# Altium schematic sheet SCT3811 and CMX940 EVM_V2_20220408.SCHDOC
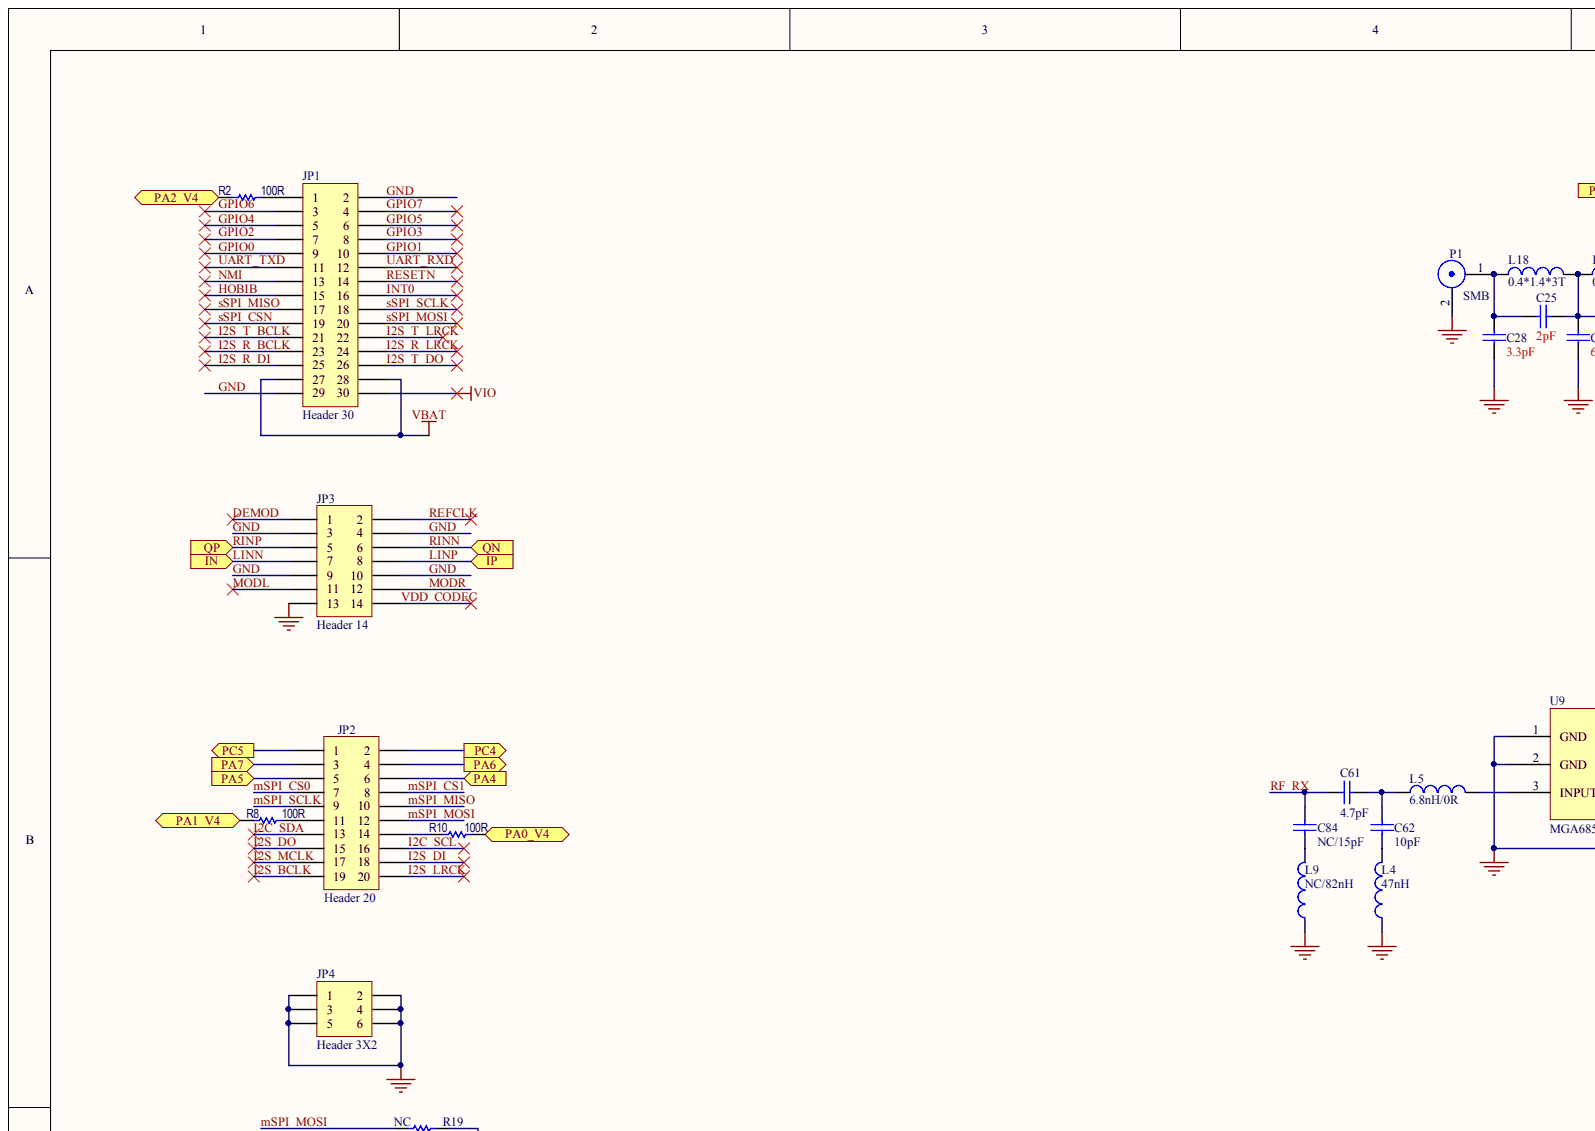
[diagram p0: SCT3811 and CMX940 EVM_V2_20220408.SCHDOC, top left]
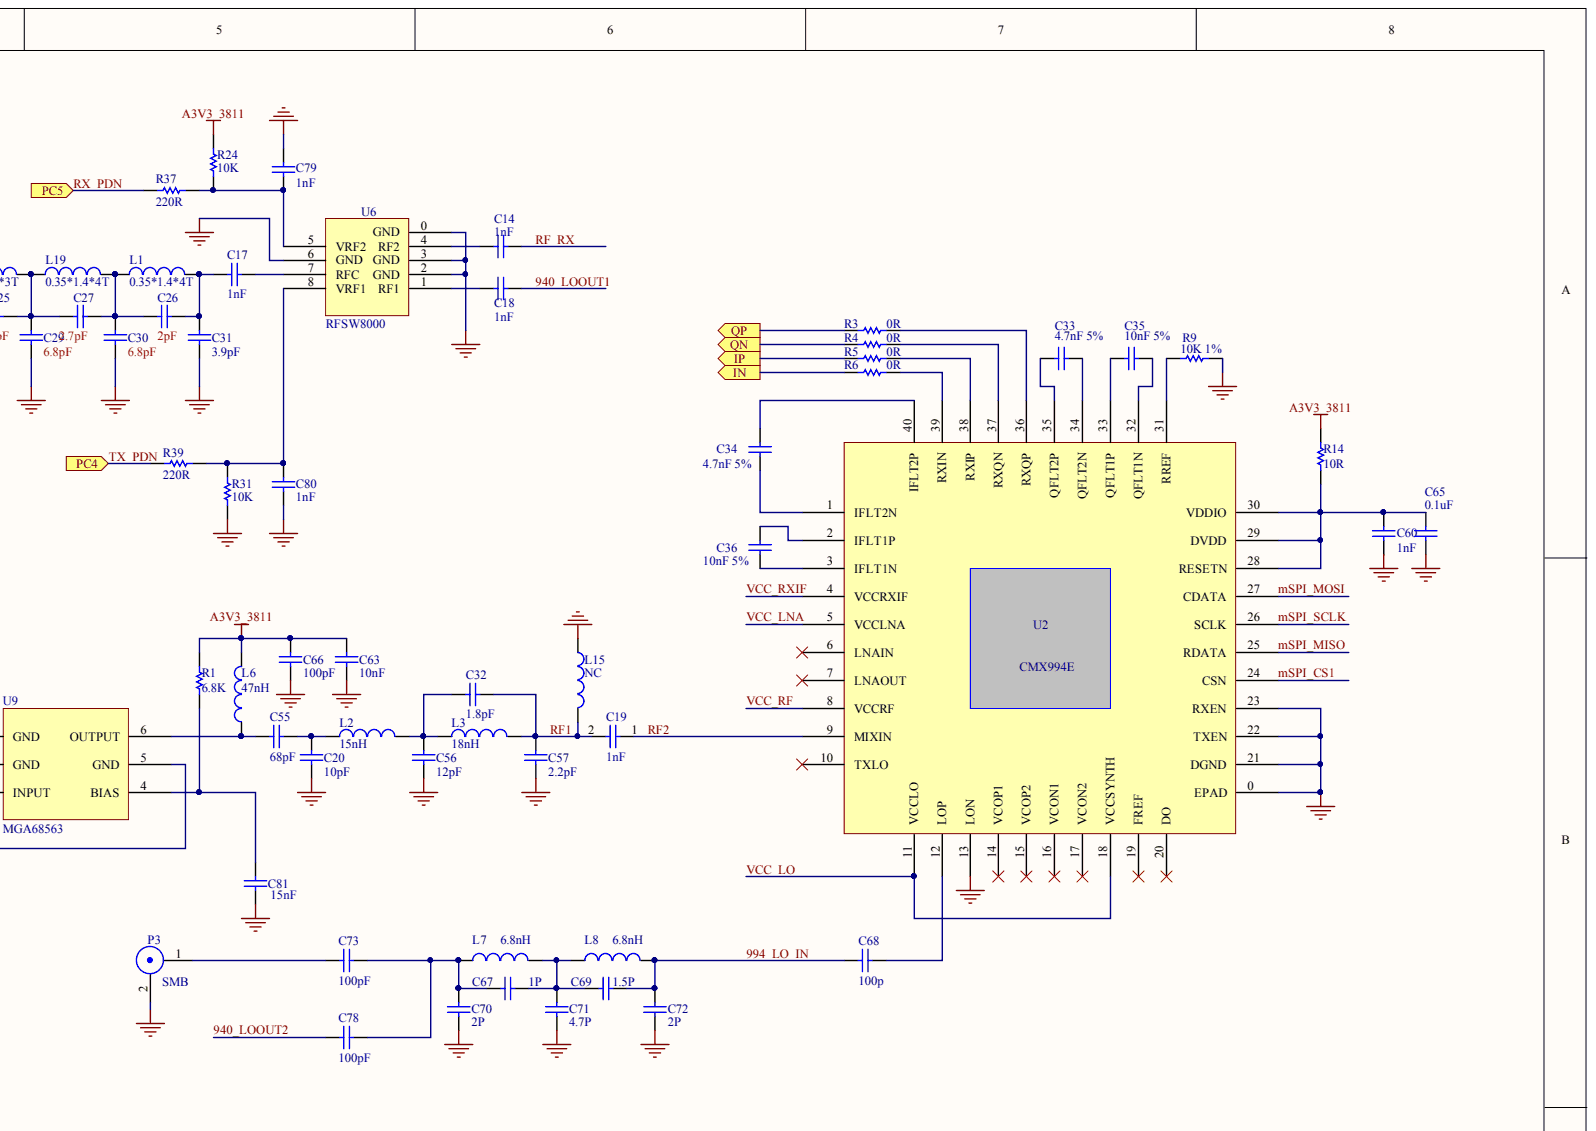
[diagram p1: SCT3811 and CMX940 EVM_V2_20220408.SCHDOC, top right]
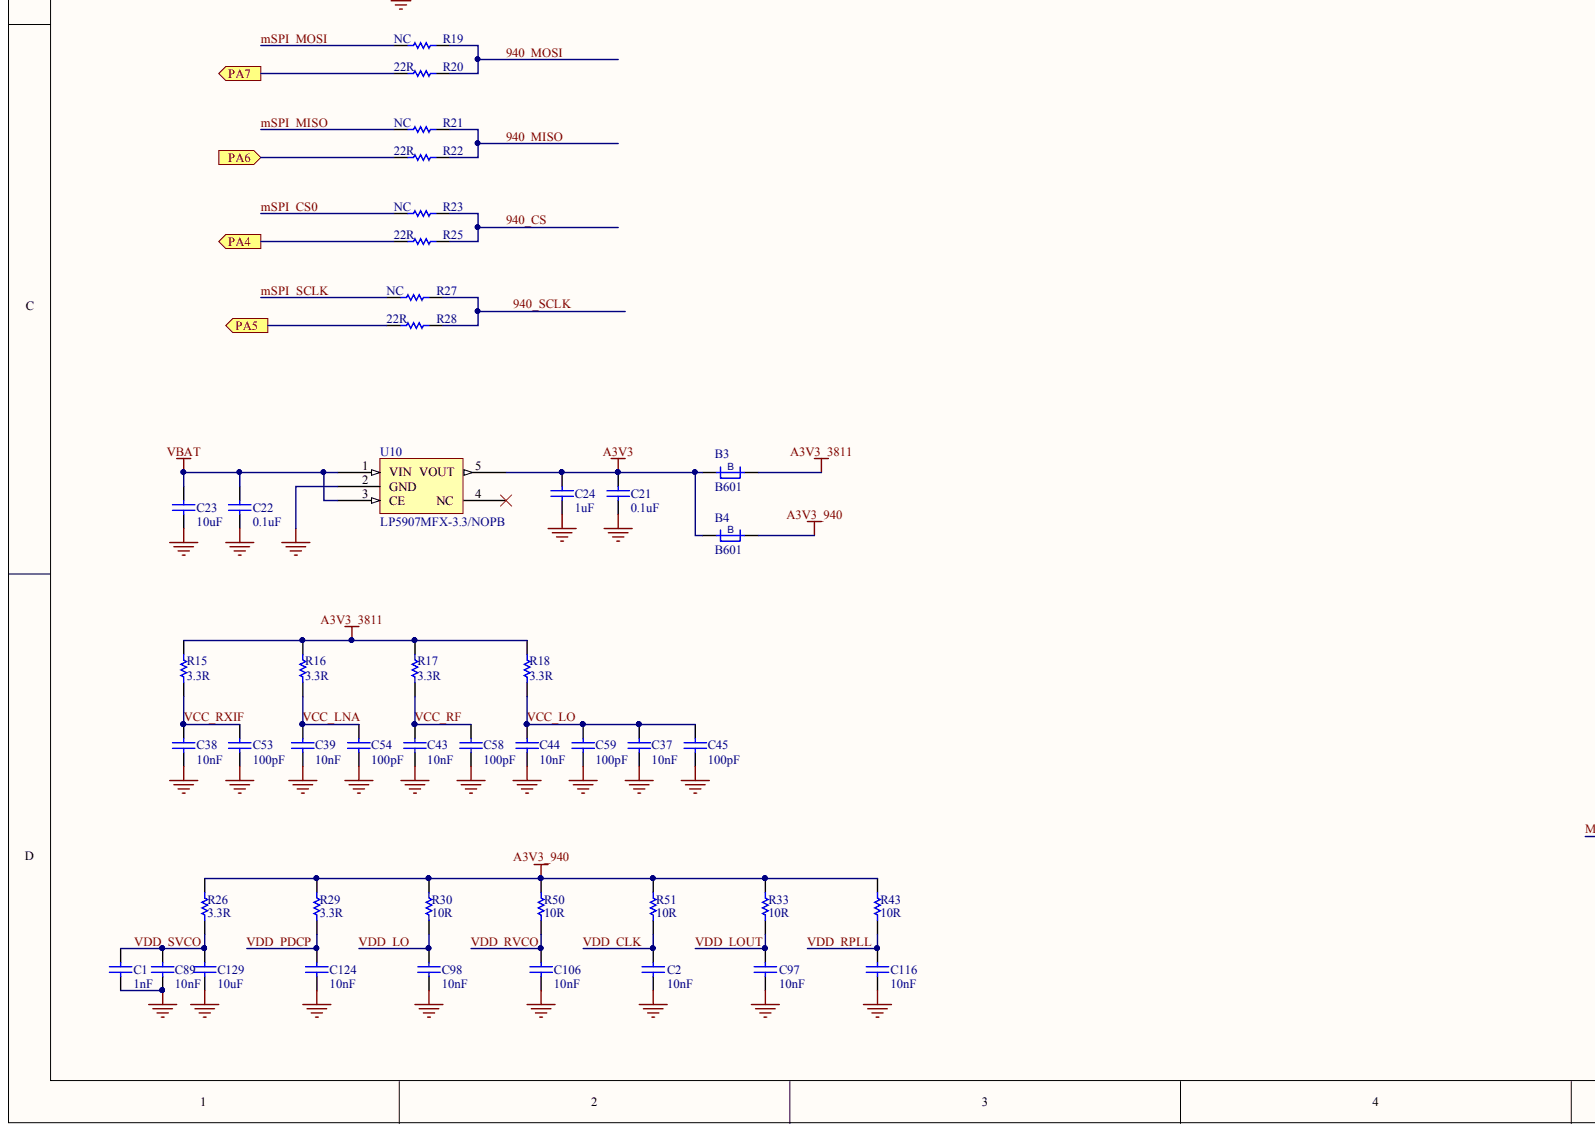
[diagram p2: SCT3811 and CMX940 EVM_V2_20220408.SCHDOC, bottom left]
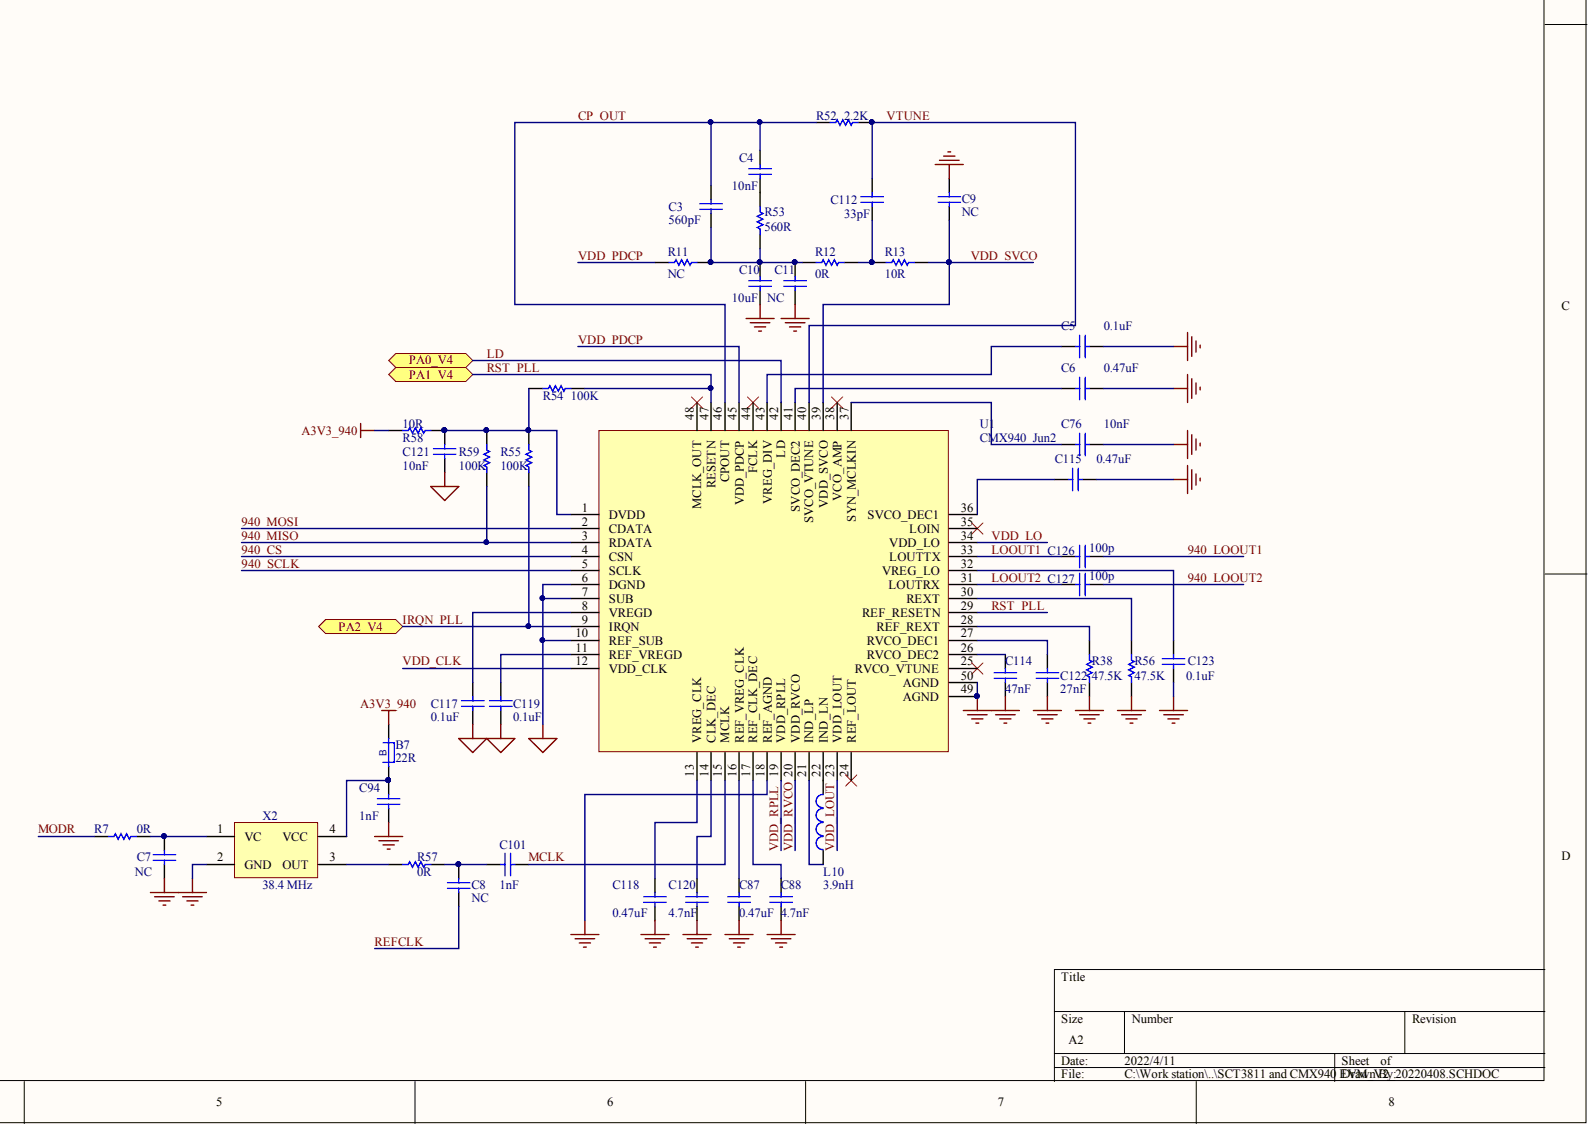
[diagram p3: SCT3811 and CMX940 EVM_V2_20220408.SCHDOC, bottom right]

## Parts
B3  B601  [0402]
B4  B601  [0402]
B7  22R  [0402]
C1  1nF  [0402]
C2  10nF  [0402]
C3  560pF  [0402]
C4  10nF  [0603S]
C5  0.1uF  [0402]
C6  0.47uF  [0402]
C7  NC  [0402]
C8  NC  [0402]
C9  NC  [0603S]
C10  10uF  [0603]
C11  NC  [0603S]
C14  1nF  [0402]
C17  1nF  [0402]
C18  1nF  [0402]
C19  1nF  [0402]
C20  10pF  [0402]
C21  0.1uF  [0402]
C22  0.1uF  [0402]
C23  10uF  [0603]
C24  1uF  [0402]
C25  2pF  [0603-MINI]
C26  2pF  [0603-MINI]
C27  2.7pF  [0603-MINI]
C28  3.3pF  [0603-MINI]
C29  6.8pF  [0603-MINI]
C30  6.8pF  [0603-MINI]
C31  3.9pF  [0603-MINI]
C32  1.8pF  [0402]
C33  4.7nF 5%  [0402]
C34  4.7nF 5%  [0402]
C35  10nF 5%  [0402]
C36  10nF 5%  [0402]
C37  10nF  [0402]
C38  10nF  [0402]
C39  10nF  [0402]
C43  10nF  [0402]
C44  10nF  [0402]
C45  100pF  [0402]
C53  100pF  [0402]
C54  100pF  [0402]
C55  68pF  [0402]
C56  12pF  [0402]
C57  2.2pF  [0402]
C58  100pF  [0402]
C59  100pF  [0402]
C60  1nF  [0402]
C61  4.7pF  [0402]
C62  10pF  [0402]
C63  10nF  [0402]
C65  0.1uF  [0402]
C66  100pF  [0402]
C67  1P  [0402]
C68  100p  [0402]
C69  1.5P  [0402]
C70  2P  [0402]
C71  4.7P  [0402]
C72  2P  [0402]
C73  100pF  [0402]
C76  10nF  [0402]
C78  100pF  [0402]
C79  1nF  [0402]
C80  1nF  [0402]
C81  15nF  [0402]
C84  NC/15pF  [0402]
C87  0.47uF  [0402]
C88  4.7nF  [0402]
C89  10nF  [0402]
C94  1nF  [0402]
C97  10nF  [0402]
C98  10nF  [0402]
C101  1nF  [0402]
C106  10nF  [0402]
C112  33pF  [0402]
C114  47nF  [0402]
C115  0.47uF  [0402]
C116  10nF  [0402]
C117  0.1uF  [0402]
C118  0.47uF  [0402]
C119  0.1uF  [0402]
C120  4.7nF  [0402]
C121  10nF  [0402]
C122  27nF  [0402]
C123  0.1uF  [0402]
C124  10nF  [0402]
C126  100p  [0402]
C127  100p  [0402]
C129  10uF  [0603S]
JP1  Header 30  [HDR2X15]
JP2  Header 20  [HDR2X10]
JP3  Header 14  [HDR2X7]
JP4  Header 3X2  [HDR2X3]
L1  0.35*1.4*4T  [3T_MJ]
L2  15nH  [0603]
L3  18nH  [0603]
L4  47nH  [0603]
L5  6.8nH/0R  [0603]
L6  47nH  [0603]
L7  6.8nH  [0402]
L8  6.8nH  [0402]
L9  NC/82nH  [0603]
L10  3.9nH  [0402]
L15  NC  [0402]
L18  0.4*1.4*3T  [3T_MJ]
L19  0.35*1.4*4T  [3T_MJ]
P1  SMB  [SMA-2]
P3  SMB  [SMA-2]
R1  6.8K  [0402]
R2  100R  [0402]
R3  0R  [0402]
R4  0R  [0402]
R5  0R  [0402]
R6  0R  [0402]
R7  0R  [0402]
R8  100R  [0402]
R9  10K 1%  [0402]
R10  100R  [0402]
R11  NC  [0402]
R12  0R  [0402]
R13  10R  [0402]
R14  10R  [0402]
R15  3.3R  [0402]
R16  3.3R  [0402]
R17  3.3R  [0402]
R18  3.3R  [0402]
R19  NC  [0402]
R20  22R  [0402]
R21  NC  [0402]
R22  22R  [0402]
R23  NC  [0402]
R24  10K  [0402]
R25  22R  [0402]
R26  3.3R  [0402]
R27  NC  [0402]
R28  22R  [0402]
R29  3.3R  [0402]
R30  10R  [0402]
R31  10K  [0402]
R33  10R  [0402]
R37  220R  [0402]
R38  47.5K  [0402]
R39  220R  [0402]
R43  10R  [0402]
R50  10R  [0402]
R51  10R  [0402]
R52  2.2K  [0402]
R53  560R  [0402]
R54  100K  [0402]
R55  100K  [0402]
R56  47.5K  [0402]
R57  0R  [0402]
R58  10R  [0402]
R59  100K  [0402]
U1  CMX940_Jun2  [QFN50P700X700X110_HS-50N_JUN]
U2  CMX994E  [QFN40_6X6]
U6  RFSW8000  [RFSW8000]
U9  MGA68563  [SC70-6]
U10  LP5907MFX-3.3/NOPB  [SOT-25]
X2  38.4 MHz  [SMD2520]

## Ports
IN, IP, PA0_V4, PA1_V4, PA2_V4, PA4, PA5, PA6, PA7, PC4, PC5, QN, QP

## Nets
A3V3: B3.1 B4.1 C21.2 C24.2 U10.5(VOUT)
A3V3_940: B4.2 B7.2 R26.2 R29.2 R30.2 R33.2 R43.2 R50.2 R51.2 R58.2
A3V3_3811: B3.2 C63.1 C66.1 L6.2 R1.1 R14.2 R15.2 R16.2 R17.2 R18.2 R24.2
VBAT: C22.2 C23.2 JP1.27 JP1.28 U10.1(VIN) U10.3(CE)
VIO: JP1.30
940_CS: R23.1 R25.1 U1.4(CSN)
940_LOOUT1: C18.2 C126.1
940_LOOUT2: C78.2 C127.1
940_MISO: R21.1 R22.1 R59.2 U1.3(RDATA)
940_MOSI: R19.1 R20.1 U1.2(CDATA)
940_SCLK: R27.1 R28.1 U1.5(SCLK)
994_LO_IN: C68.2 C69.2 C72.1 L8.2
CP_OUT: C3.1 C4.1 R52.2 U1.46(CPOUT)
DEMOD: JP3.1
GND: C1.1 C2.1 C5.1 C6.1 C7.1 C9.1 C10.2 C11.2 C20.1 C21.1 C22.1 C23.1 C24.1 C28.1 C29.1 C30.2 C31.1 C37.2 C38.2 C39.2 C43.2 C44.2 C45.2 C53.2 C54.2 C56.1 C57.1 C58.2 C59.2 C60.2 C63.2 C65.2 C66.2 C70.2 C71.2 C72.2 C76.2 C79.2 C80.2 C81.2 C87.1 C88.1 C89.1 C94.1 C97.1 C98.1 C106.1 C114.1 C115.1 C116.1 C117.1 C118.1 C119.1 C120.1 C121.1 C122.1 C123.1 C124.1 C129.1 JP1.2 JP1.29 JP3.3 JP3.4 JP3.9 JP3.10 JP3.13 JP4.1 JP4.2 JP4.3 JP4.4 JP4.5 JP4.6 L4.1 L9.1 L15.1 P1.2 P3.2 R9.2 R31.2 R38.1 R56.1 U1.6(DGND) U1.7(SUB) U1.10(REF_SUB) U1.18(REF_AGND) U1.49(AGND) U1.50(AGND) U2.0(EPAD) U2.13(LON) U2.21(DGND) U2.22(TXEN) U2.23(RXEN) U6.0(GND) U6.2(GND) U6.3(GND) U6.6(GND) U9.1(GND) U9.2(GND) U9.5(GND) U10.2(GND) X2.2(GND)
GPIO0: JP1.9
GPIO1: JP1.10
GPIO2: JP1.7
GPIO3: JP1.8
GPIO4: JP1.5
GPIO5: JP1.6
GPIO6: JP1.3
GPIO7: JP1.4
HOBIB: JP1.15
I2C_SCL: JP2.16
I2C_SDA: JP2.13
I2S_BCLK: JP2.19
I2S_DI: JP2.18
I2S_DO: JP2.15
I2S_LRCK: JP2.20
I2S_MCLK: JP2.17
I2S_R_BCLK: JP1.23
I2S_R_DI: JP1.25
I2S_R_LRCK: JP1.24
I2S_T_BCLK: JP1.21
I2S_T_DO: JP1.26
I2S_T_LRCK: JP1.22
INT0: JP1.16
IRQN_PLL: R2.1 R55.2 U1.9(IRQN) ; port PA2_V4
LD: R10.2 U1.42(LD) ; port PA0_V4
LINN: JP3.7 R6.1 ; port IN
LINP: JP3.8 R5.1 ; port IP
LOOUT1: C126.2 U1.33(LOUTTX)
LOOUT2: C127.2 U1.31(LOUTRX)
MCLK: C101.1 U1.15(MCLK)
MODL: JP3.11
MODR: JP3.12 R7.1
MSPI_CS0: JP2.7 R23.2
MSPI_CS1: JP2.8 U2.24(CSN)
MSPI_MISO: JP2.10 R21.2 U2.25(RDATA)
MSPI_MOSI: JP2.12 R19.2 U2.27(CDATA)
MSPI_SCLK: JP2.9 R27.2 U2.26(SCLK)
NMI: JP1.13
PA4: JP2.6 R25.2 ; port PA4
PA5: JP2.5 R28.2 ; port PA5
PA6: JP2.4 R22.2 ; port PA6
PA7: JP2.3 R20.2 ; port PA7
REFCLK: C8.2 JP3.2
RESETN: JP1.14
RF1: C19.2 C32.1 C57.2 L3.2 L15.2
RF2: C19.1 U2.9(MIXIN)
RF_RX: C14.2 C61.2 C84.1
RINN: JP3.6 R4.1 ; port QN
RINP: JP3.5 R3.1 ; port QP
RST_PLL: R8.1 R54.1 U1.29(REF_RESETN) U1.47(RESETN) ; port PA1_V4
RX_PDN: JP2.1 R37.1 ; port PC5
SSPI_CSN: JP1.19
SSPI_MISO: JP1.17
SSPI_MOSI: JP1.20
SSPI_SCLK: JP1.18
TX_PDN: JP2.2 R39.2 ; port PC4
UART_RXD: JP1.12
UART_TXD: JP1.11
VCC_LNA: C39.1 C54.1 R16.1 U2.5(VCCLNA)
VCC_LO: C37.1 C44.1 C45.1 C59.1 R18.1 U2.11(VCCLO) U2.18(VCCSYNTH)
VCC_RF: C43.1 C58.1 R17.1 U2.8(VCCRF)
VCC_RXIF: C38.1 C53.1 R15.1 U2.4(VCCRXIF)
VDD_CLK: C2.2 R51.1 U1.12(VDD_CLK)
VDD_CODEC: JP3.14
VDD_LO: C98.2 R30.1 U1.34(VDD_LO)
VDD_LOUT: C97.2 R33.1 U1.23(VDD_LOUT)
VDD_PDCP: C124.2 R11.1 R29.1 U1.45(VDD_PDCP)
VDD_RPLL: C116.2 R43.1 U1.19(VDD_RPLL)
VDD_RVCO: C106.2 R50.1 U1.20(VDD_RVCO)
VDD_SVCO: C1.2 C9.2 C89.2 C129.2 R13.2 R26.1 U1.39(VDD_SVCO)
VTUNE: C112.1 R52.1 U1.40(SVCO_VTUNE)
NetB7_1: B7.1 C94.2 X2.4(VCC)
NetC3_2: C3.2 C10.1 C11.1 R11.2 R12.1 R53.2
NetC4_2: C4.2 R53.1
NetC5_2: C5.2 U1.43(VREG_DIV)
NetC6_2: C6.2 U1.41(SVCO_DEC2)
NetC7_2: C7.2 R7.2 X2.1(VC)
NetC8_1: C8.1 C101.2 R57.1
NetC14_1: C14.1 U6.4(RF2)
NetC17_1: C17.1 C26.2 C31.2 L1.2
NetC17_2: C17.2 U6.7(RFC)
NetC18_1: C18.1 U6.1(RF1)
NetC20_2: C20.2 C55.2 L2.1
NetC25_1: C25.1 C28.2 L18.1 P1.1
NetC25_2: C25.2 C27.2 C29.2 L18.2 L19.1
NetC26_1: C26.1 C27.1 C30.1 L1.1 L19.2
NetC32_2: C32.2 C56.2 L2.2 L3.1
NetC33_1: C33.1 U2.34(QFLT2N)
NetC33_2: C33.2 U2.35(QFLT2P)
NetC34_1: C34.1 U2.1(IFLT2N)
NetC34_2: C34.2 U2.40(IFLT2P)
NetC35_1: C35.1 U2.32(QFLT1N)
NetC35_2: C35.2 U2.33(QFLT1P)
NetC36_1: C36.1 U2.3(IFLT1N)
NetC36_2: C36.2 U2.2(IFLT1P)
NetC55_1: C55.1 L6.1 U9.6(OUTPUT)
NetC60_1: C60.1 C65.1 R14.1 U2.28(RESETN) U2.29(DVDD) U2.30(VDDIO)
NetC61_1: C61.1 C62.1 L5.1
NetC62_2: C62.2 L4.2
NetC67_1: C67.1 C70.1 C73.1 C78.1 L7.1
NetC67_2: C67.2 C69.1 C71.1 L7.2 L8.1
NetC68_1: C68.1 U2.12(LOP)
NetC73_2: C73.2 P3.1
NetC76_1: C76.1 U1.37(SYN_MCLKIN)
NetC79_1: C79.1 R24.1 R37.2 U6.5(VRF2)
NetC80_1: C80.1 R31.1 R39.1 U6.8(VRF1)
NetC81_1: C81.1 R1.2 U9.4(BIAS)
NetC84_2: C84.2 L9.2
NetC87_2: C87.2 U1.16(REF_VREG_CLK)
NetC88_2: C88.2 U1.17(REF_CLK_DEC)
NetC112_2: C112.2 R12.2 R13.1
NetC114_2: C114.2 U1.26(RVCO_DEC2)
NetC115_2: C115.2 U1.36(SVCO_DEC1)
NetC117_2: C117.2 U1.8(VREGD)
NetC118_2: C118.2 U1.13(VREG_CLK)
NetC119_2: C119.2 U1.11(REF_VREGD)
NetC120_2: C120.2 U1.14(CLK_DEC)
NetC121_2: C121.2 R54.2 R55.1 R58.1 R59.1 U1.1(DVDD)
NetC122_2: C122.2 U1.27(RVCO_DEC1)
NetC123_2: C123.2 U1.32(VREG_LO)
NetJP1_1: JP1.1 R2.2
NetJP2_11: JP2.11 R8.2
NetJP2_14: JP2.14 R10.1
NetL5_2: L5.2 U9.3(INPUT)
NetL10_1: L10.1 U1.21(IND_LP)
NetL10_2: L10.2 U1.22(IND_LN)
NetR3_2: R3.2 U2.36(RXQP)
NetR4_2: R4.2 U2.37(RXQN)
NetR5_2: R5.2 U2.38(RXIP)
NetR6_2: R6.2 U2.39(RXIN)
NetR9_1: R9.1 U2.31(RREF)
NetR38_2: R38.2 U1.28(REF_REXT)
NetR56_2: R56.2 U1.30(REXT)
NetR57_2: R57.2 X2.3(OUT)

Not connected: U1 24(REF_LOUT) 25(RVCO_VTUNE) 35(LOIN) 38(VCO_AMP) 44(FCLK) 48(MCLK_OUT); U2 6(LNAIN) 7(LNAOUT) 10(TXLO) 14(VCOP1) 15(VCOP2) 16(VCON1) 17(VCON2) 19(FREF) 20(DO); U10 4(NC)

## Library data of the parts
B3 B4 B7 = B: Component Kind: Standard; Library Name: SCH Lib.SCHLIB; Revision: July-2002: Re-released for DXP Platform.
C1-C11 C67-C72 C87-C89 C94 C97 C98 C101 C106 C112 C114-C124 C126 C127 C129 = Cap: Capacitor; Manufacturer: Generic Components; Class: Passive; Value: 100pF; Component Kind: Standard; Library Name: Miscellaneous Devices.IntLib; Published: 08/06/2000; Signal Integrity: Cap; Simulation: CAP; SubClass: Capacitor; Publisher: Altium Hobart Technology Centre
C14 C17 C18 C25 C26 C31 C79 C80 = Cap: Capacitor; Value: 2.2uF; Component Kind: Standard; Library Name: Miscellaneous Devices.IntLib; Published: 8-Jun-2000; Revision: July-2002: Re-released for DXP Platform.; Signal Integrity: Cap; Simulation: CAP; Publisher: Altium Limited
C19 C20 C32-C39 C43-C45 C53-C63 C65 C66 C73 C76 C78 C84 = Cap: Capacitor; Manufacturer: Generic Components; Class: Passive; Component Kind: Standard; Library Name: Miscellaneous Devices.IntLib; Published: 08/06/2000; Signal Integrity: Cap; Simulation: CAP; SubClass: Capacitor; Publisher: Altium Hobart Technology Centre
  C73 C78: Value: 100pF
C21-C24 = Cap Semi: Capacitor (Semiconductor SIM Model); Manufacturer: Generic Components; Class: Passive; Value: 100pF; Component Kind: Standard; Library Name: Miscellaneous Devices.IntLib; Published: 08/06/2000; Signal Integrity: Cap Semi; Simulation: CAP; SubClass: Capacitor; Publisher: Altium Hobart Technology Centre
C27 C28 C30 = Cap: Capacitor; Value: 360pF; Component Kind: Standard; Library Name: Miscellaneous Devices.IntLib; Published: 8-Jun-2000; Revision: July-2002: Re-released for DXP Platform.; Signal Integrity: Cap; Simulation: CAP; Publisher: Altium Limited
C29 = Cap: Capacitor; Value: 5.6pF; Component Kind: Standard; Library Name: Miscellaneous Devices.IntLib; Published: 8-Jun-2000; Revision: July-2002: Re-released for DXP Platform.; Signal Integrity: Cap; Simulation: CAP; Publisher: Altium Limited
C81 = Cap Semi: Capacitor (Semiconductor SIM Model); Value: 4.7uF; Component Kind: Standard; Library Name: Miscellaneous Devices.IntLib; Published: 8-Jun-2000; Revision: July-2002: Re-released for DXP Platform.; Signal Integrity: Cap Semi; Simulation: CAP; Publisher: Altium Limited
JP1 = Header 15X2: Header, 15-Pin, Dual row; Component Kind: Standard; LatestRevisionDate: 17-Jul-2002; LatestRevisionNote: Re-released for DXP Platform.; Library Name: Miscellaneous Connectors.IntLib; Signal Integrity: Connector; Publisher: Altium Limited
JP2 = Header 10X2: Header, 10-Pin, Dual row; Component Kind: Standard; LatestRevisionDate: 17-Jul-2002; LatestRevisionNote: Re-released for DXP Platform.; Library Name: Miscellaneous Connectors.IntLib; Signal Integrity: Connector; Publisher: Altium Limited
JP3 = Header 7X2: Header, 7-Pin, Dual row; Component Kind: Standard; LatestRevisionDate: 17-Jul-2002; LatestRevisionNote: Re-released for DXP Platform.; Library Name: Miscellaneous Connectors.IntLib; Signal Integrity: Connector; Publisher: Altium Limited
JP4 = Header 3X2: Header, 3-Pin, Dual row; Component Kind: Standard; LatestRevisionDate: 17-Jul-2002; LatestRevisionNote: Re-released for DXP Platform.; Library Name: Miscellaneous Connectors.IntLib; Signal Integrity: Connector; Publisher: Altium Limited
L1 L7 L8 L10 L15 L18 L19 = Inductor: Value: 47nH; Component Kind: Standard; Description: Inductor; Library Name: Miscellaneous Devices.IntLib; Published: 8-Jun-2000; Revision: July-2002: Re-released for DXP Platform.; Signal Integrity: Inductor; Simulation: INDUCTOR; Publisher: Altium Limited
L2-L6 L9 = Inductor: Manufacturer: Generic Components; Class: Passive; Component Kind: Standard; Description: Inductor; Library Name: Miscellaneous Devices.IntLib; Published: 08/06/2000; Signal Integrity: Inductor; Simulation: INDUCTOR; SubClass: Inductor; Publisher: Altium Hobart Technology Centre
P1 P3 = SMB: SBM Straight Connector; Manufacturer: Generic Components; SubClass: RF Coaxial; Class: Connector; Component Kind: Standard; Library Name: Miscellaneous Connectors.IntLib; Signal Integrity: Connector
R1 R3-R7 R9 R11-R31 R33 R37 R39 R43 R50-R55 R58 R59 = Res Semi: Semiconductor Resistor; Manufacturer: Generic Components; Class: Passive; Component Kind: Standard; Library Name: Miscellaneous Devices.IntLib; Published: 08/06/2000; Signal Integrity: Res Semi; Simulation: RES; SubClass: Resistor; Publisher: Altium Hobart Technology Centre
R2 R8 R10 R38 R56 R57 = Res1: Resistor; Manufacturer: Generic Components; Class: Passive; Value: 1K; Component Kind: Standard; Published: 08/06/2000; Signal Integrity: Res1; Simulation: RESISTOR; SubClass: Resistor; Publisher: Altium Hobart Technology Centre
  R2 R8 R10: Library Name: RF.SCHLIB
  R38 R56 R57: Library Name: Miscellaneous Devices.IntLib
U2 = CMX994: Component Kind: Standard; Library Name: SCH Lib.SCHLIB
U10 = LCO_SOT25: LDO SOT25 封装, CE为控制端; Component Kind: Standard; Library Name: SCH Lib.SCHLIB
X2 = TCXO_12.8MHZ: Component Kind: Standard; Library Name: Schlib1.SchLib
U1 = CMX940_Jun2: Component Kind: Standard; Library Name: zySCHLIB_Z.lib
U6 = RFSW8000: Component Kind: Standard; Library Name: Schlib1.SchLib
U9 = MGA68563: Component Kind: Standard; Library Name: Schlib1.SchLib
